# Altium schematic sheet sem02_2442ind.SchDoc
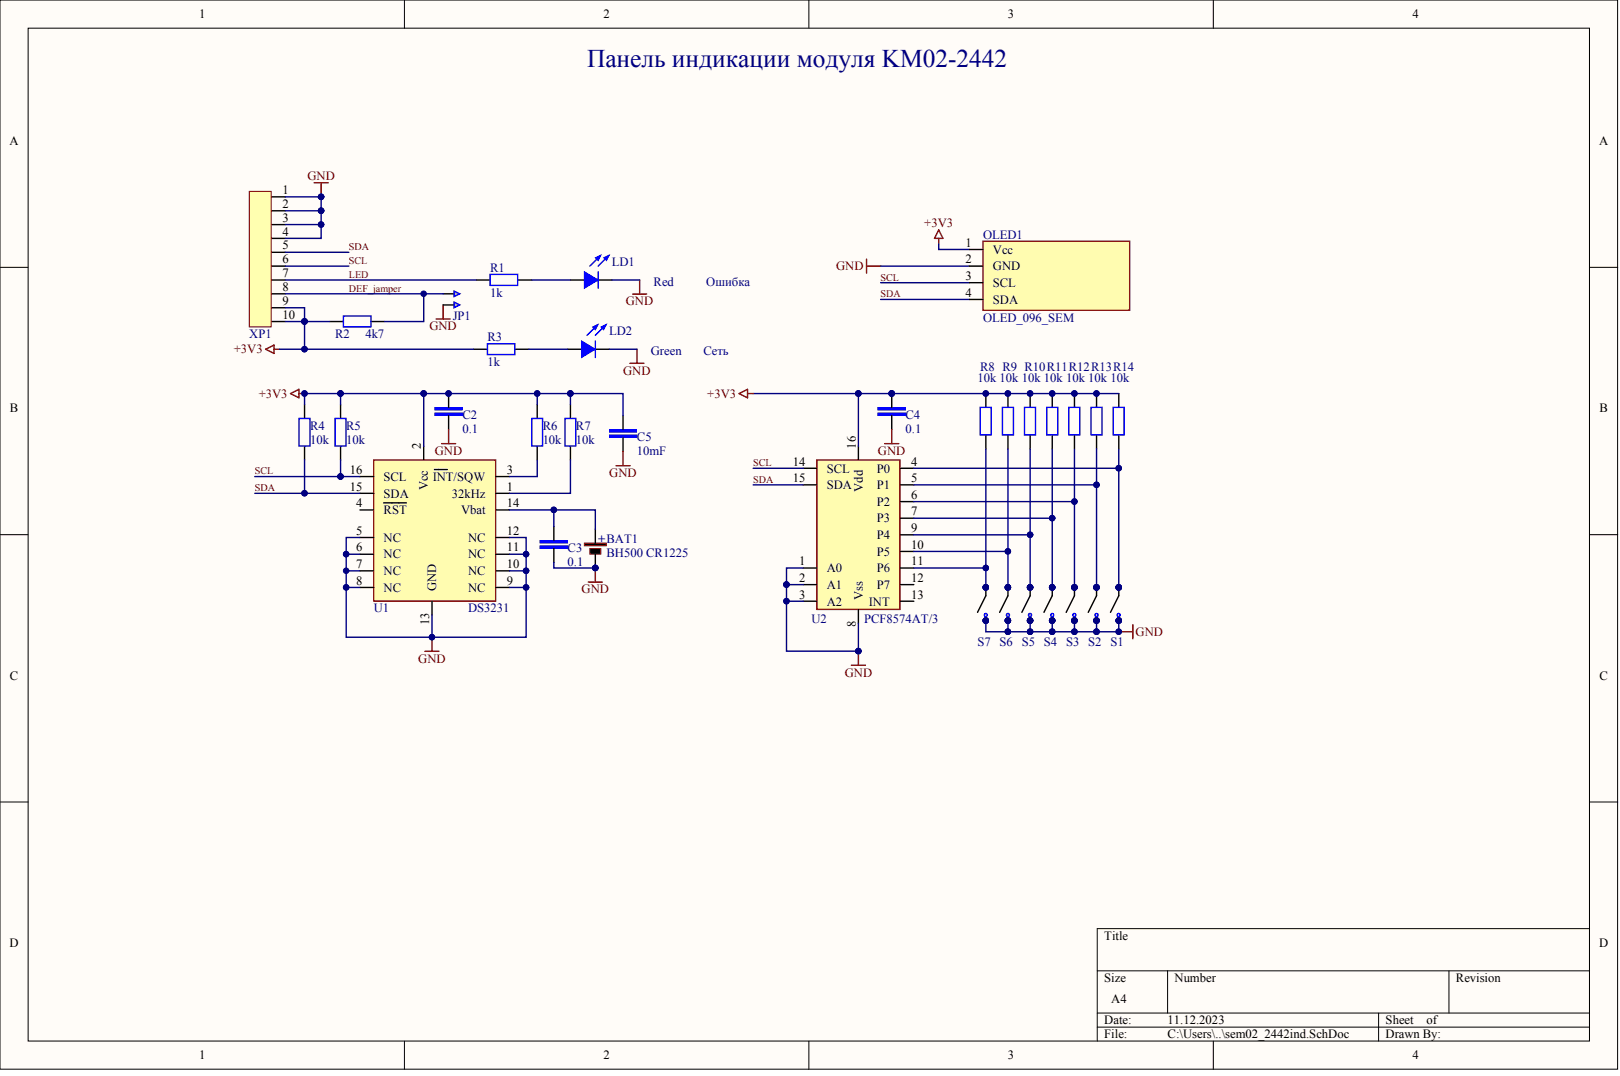
[diagram p0: sem02_2442ind.SchDoc]

## Parts
BAT1  BH500 CR1225
C2  0.1
C3  0.1
C4  0.1
C5  10mF
JP1  jamper  (value not drawn)
LD1  Red
LD2  Green
OLED1  OLED_096_SEM
R1  1k
R2  4k7
R3  1k
R4  10k
R5  10k
R6  10k
R7  10k
R8  10k
R9  10k
R10  10k
R11  10k
R12  10k
R13  10k
R14  10k
S1  BUT_SW  (value not drawn)
S2  BUT_SW  (value not drawn)
S3  BUT_SW  (value not drawn)
S4  BUT_SW  (value not drawn)
S5  BUT_SW  (value not drawn)
S6  BUT_SW  (value not drawn)
S7  BUT_SW  (value not drawn)
U1  DS3231
U2  PCF8574AT/3
XP1  Conn10x1  (value not drawn)

## Nets
+3V3: C2.2 C4.2 C5.2 OLED1.1(Vcc) R2.2 R3.2 R4.1 R5.1 R6.1 R7.1 R8.1 R9.1 R10.1 R11.1 R12.1 R13.1 R14.1 U1.2(Vcc) U2.16(Vdd) XP1.9 XP1.10
GND: BAT1.2 C2.1 C3.1 C4.1 C5.1 JP1.2 LD1.2 LD2.2 OLED1.2(GND) S1.3 S1.4 S2.3 S2.4 S3.3 S3.4 S4.3 S4.4 S5.3 S5.4 S6.3 S6.4 S7.3 S7.4 U1.5(NC) U1.6(NC) U1.7(NC) U1.8(NC) U1.9(NC) U1.10(NC) U1.11(NC) U1.12(NC) U1.13(GND) U2.1(A0) U2.2(A1) U2.3(A2) U2.8(Vss) XP1.1 XP1.2 XP1.3 XP1.4
DEF_JAMPER: JP1.1 R2.1 XP1.8
LED: R1.2 XP1.7
SCL: OLED1.3(SCL) R5.2 U1.16(SCL) U2.14(SCL) XP1.6
SDA: OLED1.4(SDA) R4.2 U1.15(SDA) U2.15(SDA) XP1.5
NetBAT1_1: BAT1.1 C3.2 U1.14(Vbat)
NetLD1_1: LD1.1 R1.1
NetLD2_1: LD2.1 R3.1
NetR6_2: R6.2 U1.3(INT/SQW)
NetR7_2: R7.2 U1.1(32kHz)
NetR8_2: R8.2 S7.1 S7.2 U2.11(P6)
NetR9_2: R9.2 S6.1 S6.2 U2.10(P5)
NetR10_2: R10.2 S5.1 S5.2 U2.9(P4)
NetR11_2: R11.2 S4.1 S4.2 U2.7(P3)
NetR12_2: R12.2 S3.1 S3.2 U2.6(P2)
NetR13_2: R13.2 S2.1 S2.2 U2.5(P1)
NetR14_2: R14.2 S1.1 S1.2 U2.4(P0)

Not connected: U1 4(RST); U2 12(P7) 13(INT)
